# Altium schematic sheet Power&CH340T.SchDoc
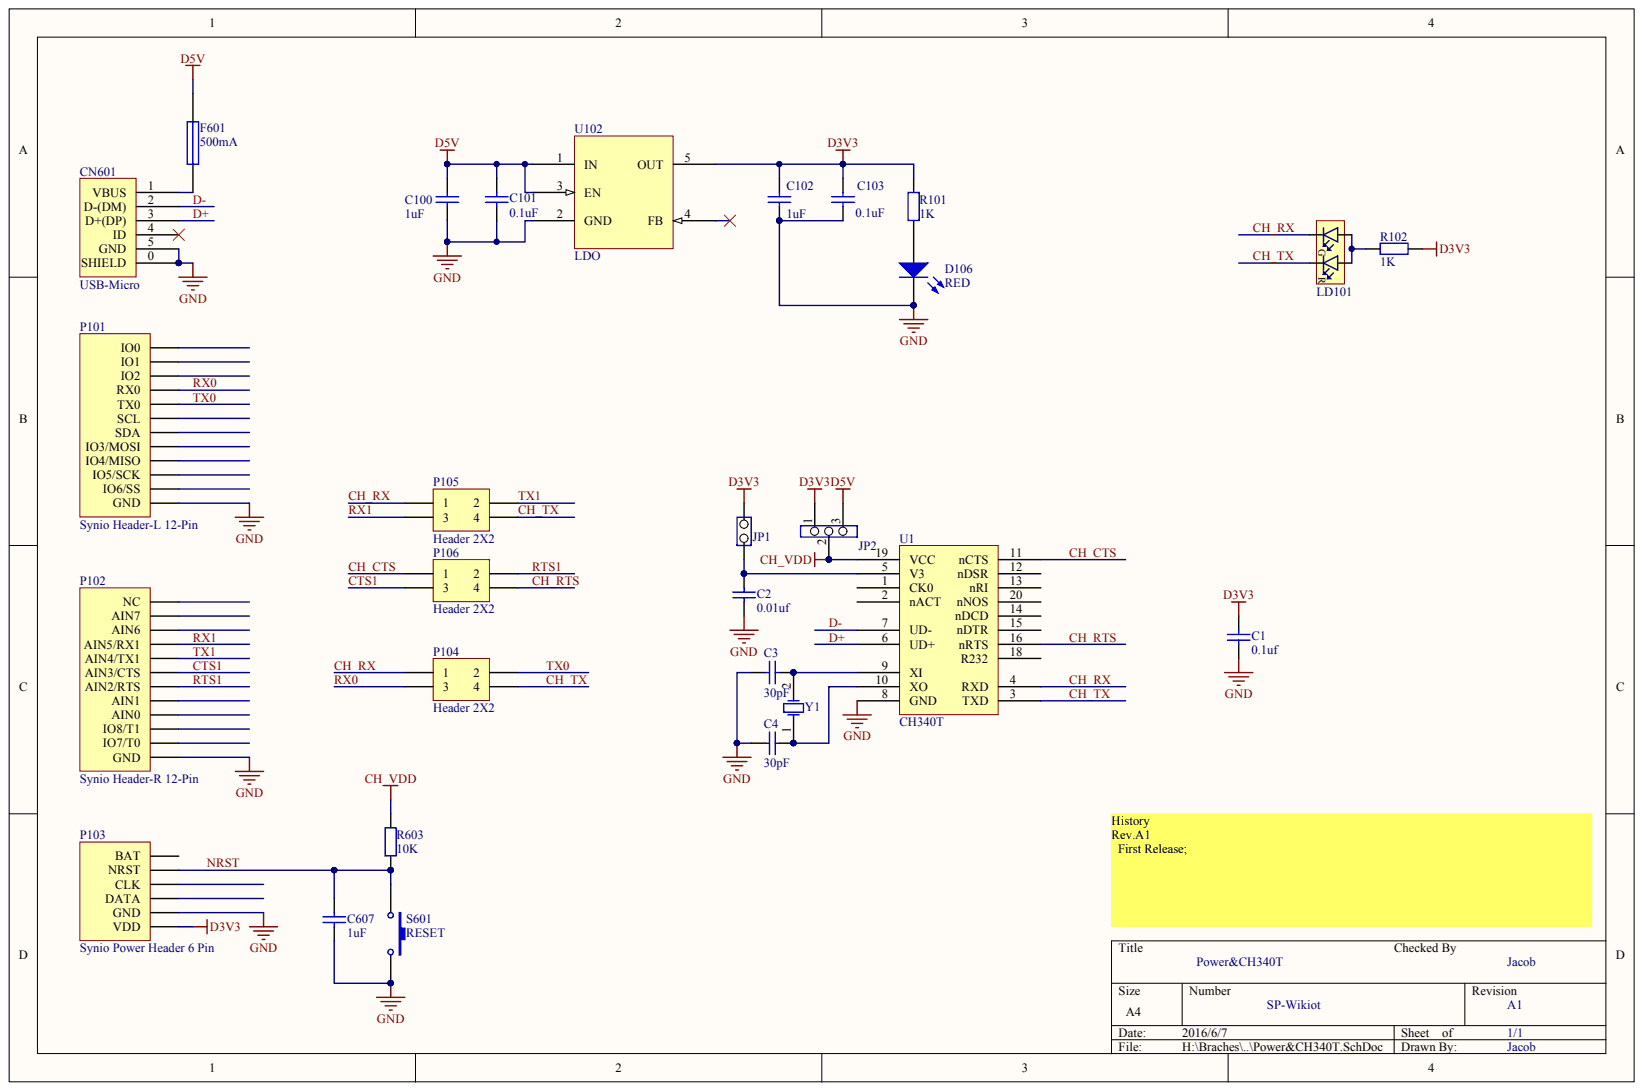
[diagram p0: Power&CH340T.SchDoc]

## Parts
C1  value 0.1uf  (hidden comment: Cap)
C2  value 0.01uf  (hidden comment: Cap)
C3  value 30pF  (hidden comment: Cap)
C4  value 30pF  (hidden comment: Cap)
C100  1uF
C101  0.1uF
C102  1uF
C103  0.1uF
C607  1uF
CN601  USB-Micro
D106  RED
F601  500mA
JP1  Jumper2-2  (value not drawn)
JP2  Jumper3  (value not drawn)
LD101  LTST-C195KGJRKT  [LED_DUAL_0606]  (value not drawn)
P101  Synio Header-L 12-Pin
P102  Synio Header-R 12-Pin
P103  Synio Power Header 6 Pin
P104  Header 2X2
P105  Header 2X2
P106  Header 2X2
R101  1K
R102  1K
R603  10K
S601  RESET
U1  CH340T
U102  LDO
Y1  XTAL  (value not drawn)

## Nets
CH_VDD: JP2.2 R603.1 U1.19(VCC)
D3V3: C1.2 C102.2 C103.2 JP1.2 JP2.1 P103.1(VDD) R101.2 R102.1 U102.5(OUT)
D5V: C100.2 C101.2 F601.1 JP2.3 U102.1(IN) U102.3(EN)
GND: C1.1 C2.2 C3.2 C4.2 C100.1 C101.1 C102.1 C103.1 C607.1 CN601.0(SHIELD) CN601.5(GND) D106.2 P101.1(GND) P102.1(GND) P103.2(GND) S601.1 U1.8(GND) U102.2(GND)
CH_CTS: P106.1 U1.11(nCTS)
CH_RTS: P106.4 U1.16(nRTS)
CH_RX: LD101.1 P104.1 P105.1 U1.4(RXD)
CH_TX: LD101.2 P104.4 P105.4 U1.3(TXD)
CTS1: P102.7(AIN3/CTS) P106.3
D+: CN601.3(D+(DP)) U1.6(UD+)
D-: CN601.2(D-(DM)) U1.7(UD-)
NRST: C607.2 P103.5(NRST) R603.2 S601.2
RTS1: P102.6(AIN2/RTS) P106.2
RX0: P101.9(RX0) P104.3
RX1: P102.9(AIN5/RX1) P105.3
TX0: P101.8(TX0) P104.2
TX1: P102.8(AIN4/TX1) P105.2
NetC2_1: C2.1 JP1.1 U1.5(V3)
NetC3_1: C3.1 U1.9(XI) Y1.2
NetC4_1: C4.1 U1.10(XO) Y1.1
NetCN601_1: CN601.1(VBUS) F601.2
NetD106_1: D106.1 R101.1
NetLD101_3: LD101.3 LD101.4 R102.2
NetU1_2: U1.2(nACT)
NetU1_12: U1.12(nDSR)
NetU1_13: U1.13(nRI)
NetU1_14: U1.14(nDCD)
NetU1_15: U1.15(nDTR)
NetU1_18: U1.18(R232)

Not connected: CN601 4(ID); P101 2(IO6/SS) 3(IO5/SCK) 4(IO4/MISO) 5(IO3/MOSI) 6(SDA) 7(SCL) 10(IO2) 11(IO1) 12(IO0); P102 2(IO7/T0) 3(IO8/T1) 4(AIN0) 5(AIN1) 10(AIN6) 11(AIN7) 12(NC); P103 3(DATA) 4(CLK) 6(BAT); U1 1(CK0) 20(nNOS); U102 4(FB)

## Library data of the parts
LD101 = (no symbol name): Manufacturer: Lite-On Inc; 2nd source part number: 859-LTST-C195KGJRKT; Note: HSMF-A203- xxxxx GaP Red GaP Emerald Green; Artikkelnr Noca: 13019145; Part Number: LTST-C195KGJRKT; Manufacturer Part Number: LTST-C195KGJRKT; Datasheet: http://media.digikey.com/pdf/Data%20Sheets/Lite-On%20PDFs/LTST-C195KGJRKT.pdf; LatestRevisionDate: 2012-07-05; Pin Count: 4; Published: 2012-07-05; Value: LTST-C195KGJRKT; Supplier: Digi-Key; Supplier part number: 160-1452-1-ND; 2nd source Supplier: Mouser; Publisher: Nordic Semiconductor
C1-C4 C100-C103 C607 CN601 D106 F601 JP1 JP2 P101-P106 R101 R102 R603 S601 U1 U102 Y1 = (no symbol name)
  C1-C4: Published: 8-Jun-2000; LatestRevisionDate: 17-Jul-2002; LatestRevisionNote: Re-released for DXP Platform.
  C100 C102 C607: Published: 8-Jun-2000; LatestRevisionDate: 17-Jul-2002; LatestRevisionNote: Re-released for DXP Platform.; PackageReference: RAD-0.3; Value: 1uF
  C101 C103: Published: 8-Jun-2000; LatestRevisionDate: 17-Jul-2002; LatestRevisionNote: Re-released for DXP Platform.; PackageReference: RAD-0.3; Value: 0.1uF
  D106: Published: 8-Jun-2000; LatestRevisionDate: 08-Jul-2005; LatestRevisionNote: Mech Layer 1 removed.; PackageReference: LED-0
  F601: Published: 8-Jun-2000; LatestRevisionDate: 17-Jul-2002; LatestRevisionNote: Re-released for DXP Platform.; PackageReference: PIN-W2/E2.8; PackageDescription: Thru-Hole; 2 Leads
  P101 P102 P104-P106: LatestRevisionDate: 17-Jul-2002; LatestRevisionNote: Re-released for DXP Platform.
  R101 R102: Published: 8-Jun-2000; LatestRevisionDate: 17-Jul-2002; LatestRevisionNote: Re-released for DXP Platform.; PackageReference: AXIAL-0.4; Value: 1K
  R603: Published: 8-Jun-2000; LatestRevisionDate: 17-Jul-2002; LatestRevisionNote: Re-released for DXP Platform.; PackageReference: AXIAL-0.4; Value: 10K
  S601: Published: 24-Mar-1999; LatestRevisionDate: 17-Jul-2002; LatestRevisionNote: Re-released for DXP Platform.; PackageReference: SPST-2
  Y1: Published: 8-Jun-2000; LatestRevisionDate: 17-Jul-2002; LatestRevisionNote: Re-released for DXP Platform.; PackageReference: R38
  C1-C4 C607 D106 F601 P101 P102 P104-P106 R101 R102 R603 S601 Y1: Publisher: Altium Limited
